# Altium schematic sheet SME_MicrocontrollerBoard_v2.1_Schematic.SchDoc(SME_MicrocontrollerBoard_v2.1_Schematic)
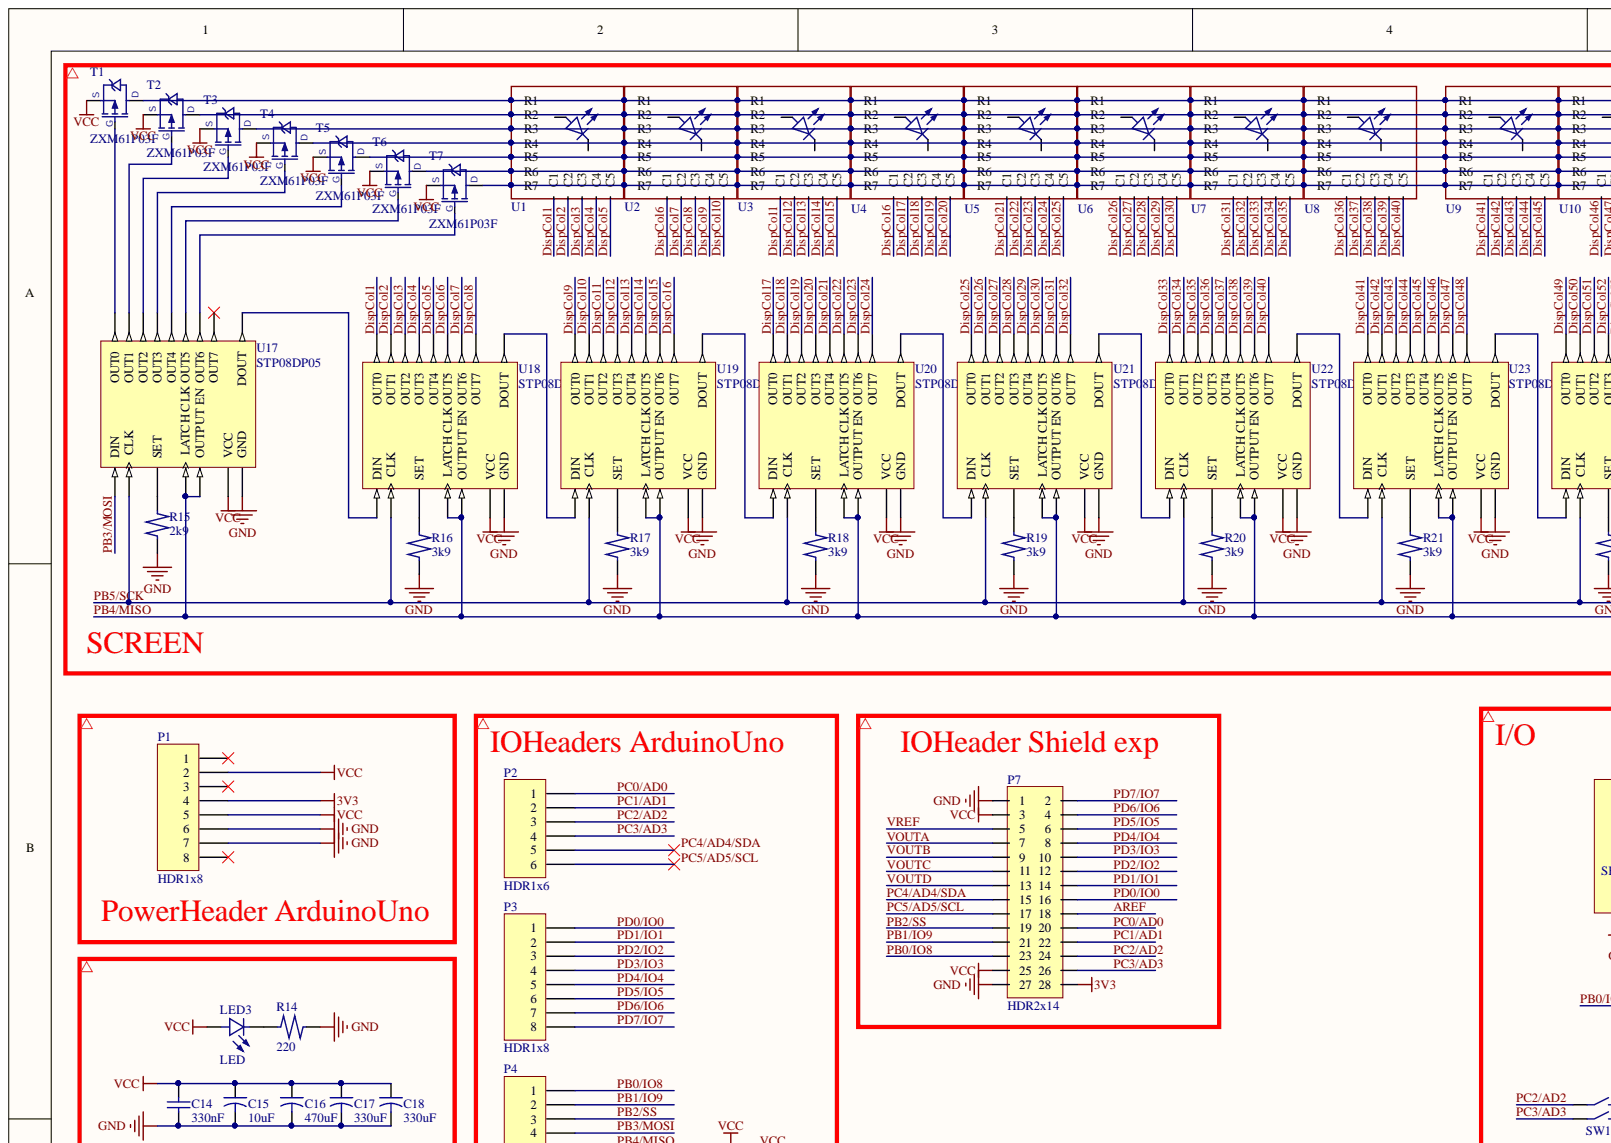
[diagram p0: SME_MicrocontrollerBoard_v2.1_Schematic.SchDoc, top left]
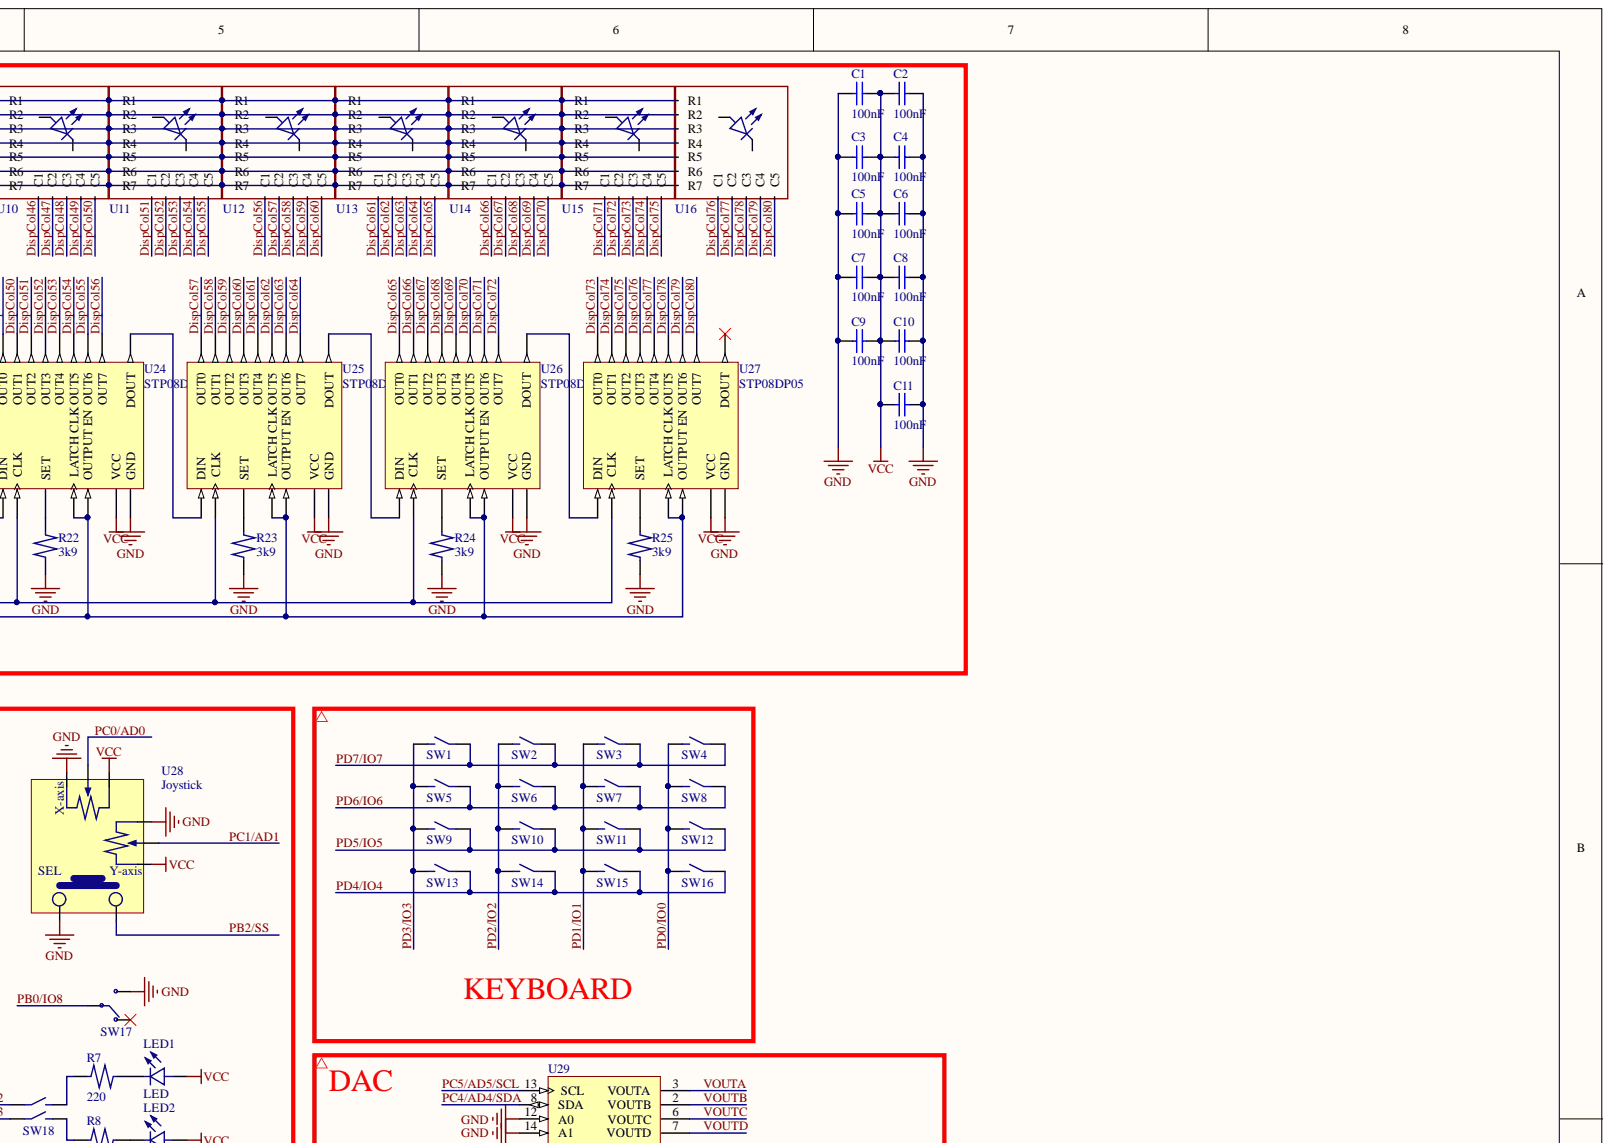
[diagram p1: SME_MicrocontrollerBoard_v2.1_Schematic.SchDoc, top right]
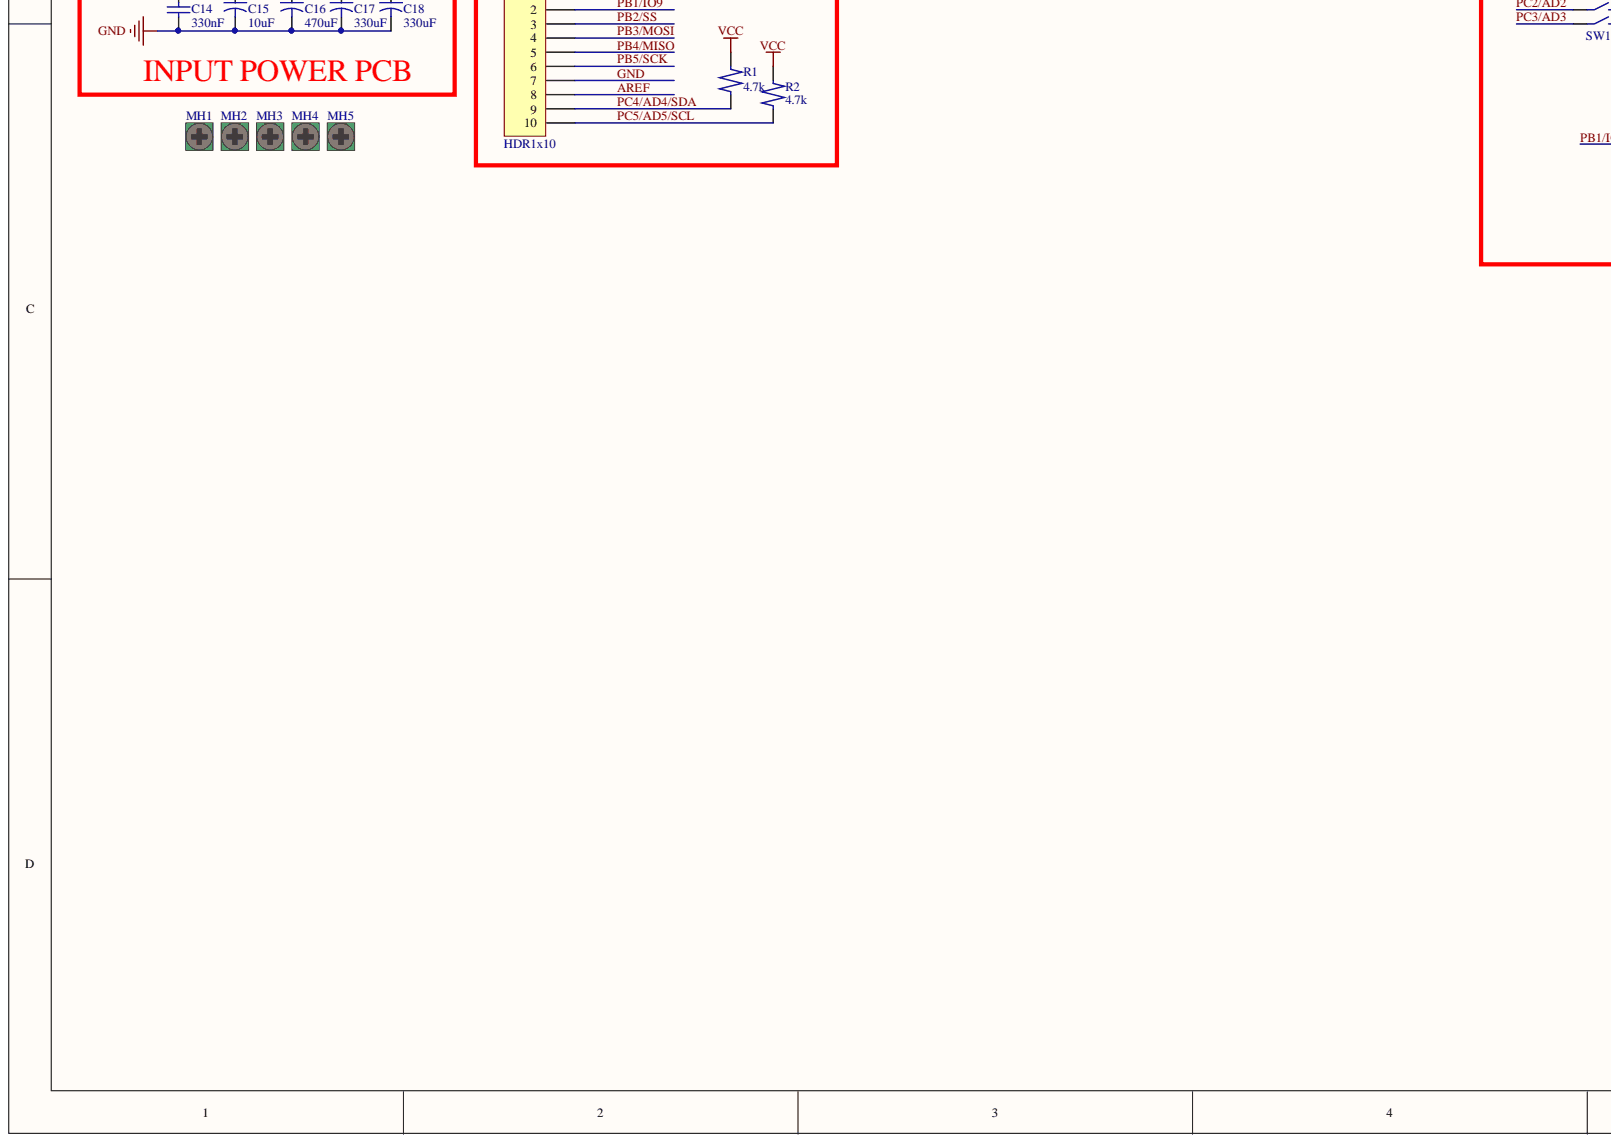
[diagram p2: SME_MicrocontrollerBoard_v2.1_Schematic.SchDoc, bottom left]
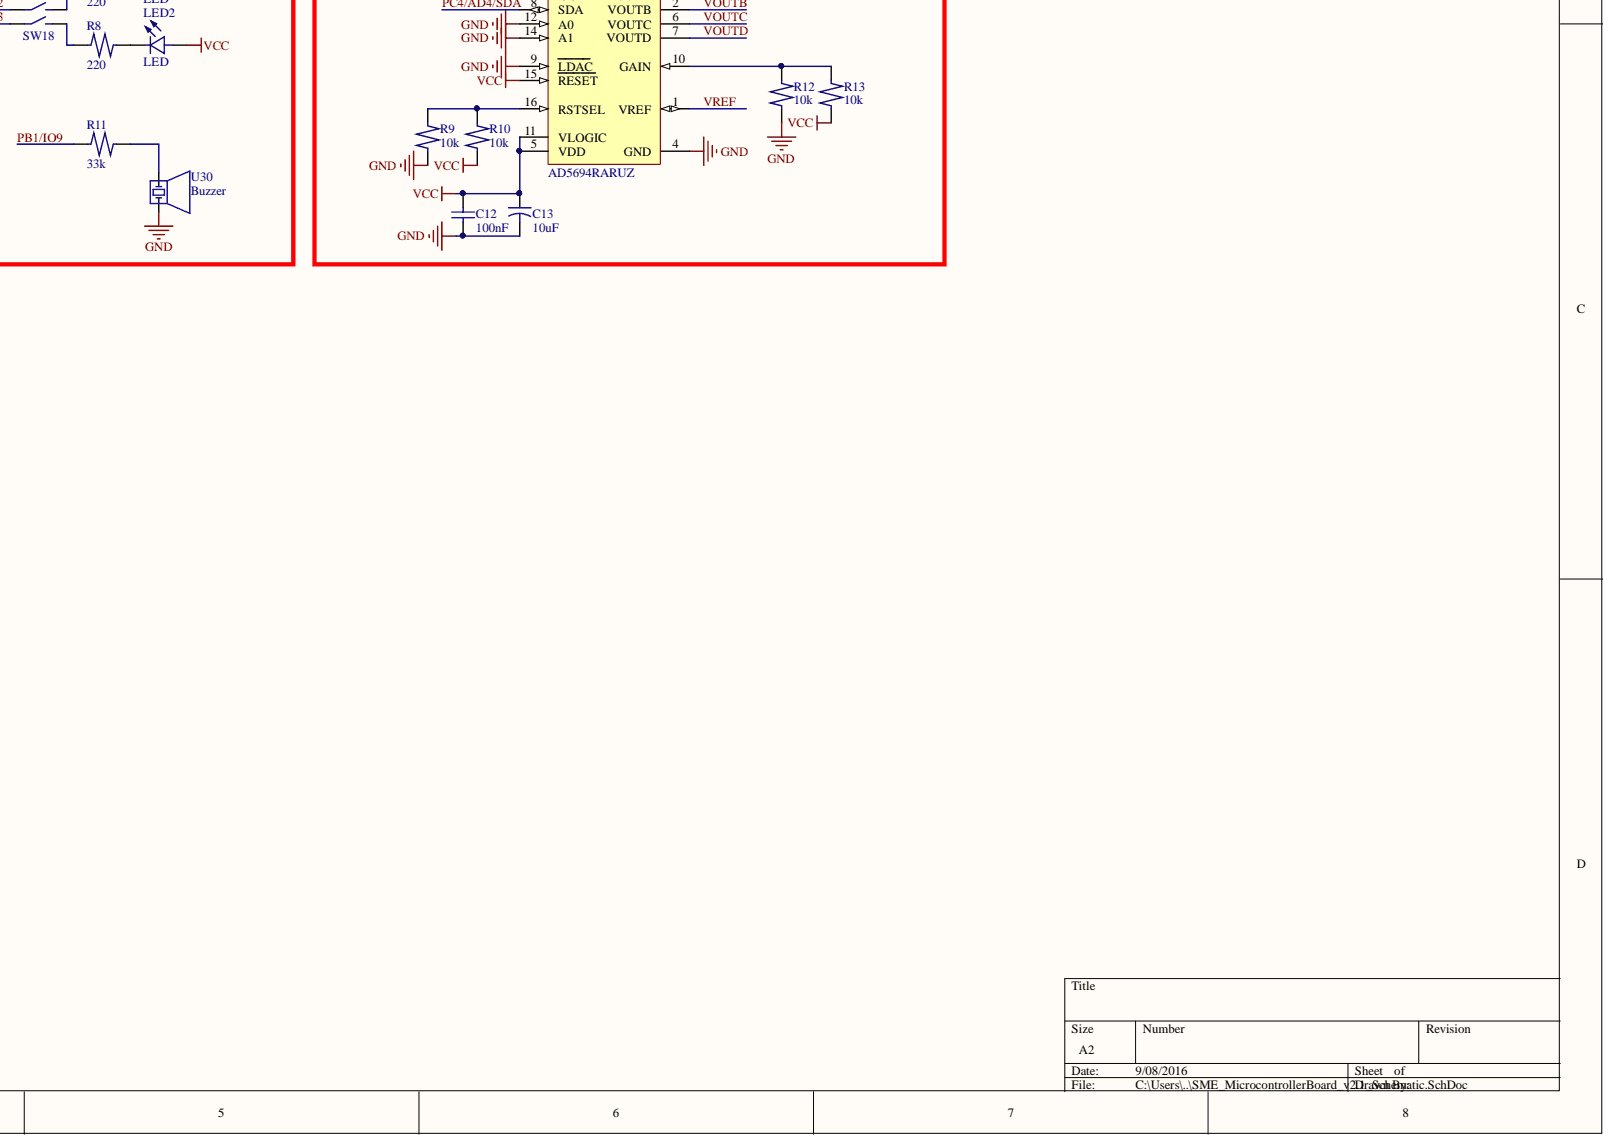
[diagram p3: SME_MicrocontrollerBoard_v2.1_Schematic.SchDoc, bottom right]

## Parts
C1  100nF  [CAPC0603(1608)_M]
C2  100nF  [CAPC0603(1608)_M]
C3  100nF  [CAPC0603(1608)_M]
C4  100nF  [CAPC0603(1608)_M]
C5  100nF  [CAPC0603(1608)_M]
C6  100nF  [CAPC0603(1608)_M]
C7  100nF  [CAPC0603(1608)_M]
C8  100nF  [CAPC0603(1608)_M]
C9  100nF  [CAPC0603(1608)_M]
C10  100nF  [CAPC0603(1608)_M]
C11  100nF  [CAPC0603(1608)_M]
C12  100nF  [CAPC0603(1608)_M]
C13  10uF  [CAPC1210(3225)_M_Polar]
C14  330nF  [CAPC0603(1608)_M]
C15  10uF  [CAPC1210(3225)_M_Polar]
C16  470uF  [CAPC1210(3225)_M_Polar]
C17  330uF  [CAPC_Gcase]
C18  330uF  [CAPC_Gcase]
LED1  LED  [LEDC0603(1608)]
LED2  LED  [LEDC0603(1608)]
LED3  LED  [LEDC0603(1608)]
MH1  Mounting Hole  [Hole_Mounting]  (value not drawn)
MH2  Mounting Hole  [Hole_Mounting]  (value not drawn)
MH3  Mounting Hole  [Hole_Mounting]  (value not drawn)
MH4  Mounting Hole  [Hole_Mounting]  (value not drawn)
MH5  Mounting Hole  [Hole_Mounting]  (value not drawn)
P1  HDR1x8  [HDR1X8_SMD]
P2  HDR1x6  [HDR1X6_SMD]
P3  HDR1x8  [HDR1X8_SMD]
P4  HDR1x10  [HDR1X10_SMD]
P7  HDR2x14  [HDR2X14_Female_RightAngle]
R1  4.7k  [RESC0603(1608)_M]
R2  4.7k  [RESC0603(1608)_M]
R7  220  [RESC0603(1608)_M]
R8  220  [RESC0603(1608)_M]
R9  10k  [RESC0603(1608)_M]
R10  10k  [RESC0603(1608)_M]
R11  33k  [RESC0603(1608)_M]
R12  10k  [RESC0603(1608)_M]
R13  10k  [RESC0603(1608)_M]
R14  220  [RESC0603(1608)_M]
R15  2k9  [RESC0603(1608)_M]
R16  3k9  [RESC0603(1608)_M]
R17  3k9  [RESC0603(1608)_M]
R18  3k9  [RESC0603(1608)_M]
R19  3k9  [RESC0603(1608)_M]
R20  3k9  [RESC0603(1608)_M]
R21  3k9  [RESC0603(1608)_M]
R22  3k9  [RESC0603(1608)_M]
R23  3k9  [RESC0603(1608)_M]
R24  3k9  [RESC0603(1608)_M]
R25  3k9  [RESC0603(1608)_M]
SW1  Switch_Tactile  [Switch_tactile_SMD_12x12]  (value not drawn)
SW2  Switch_Tactile  [Switch_tactile_SMD_12x12]  (value not drawn)
SW3  Switch_Tactile  [Switch_tactile_SMD_12x12]  (value not drawn)
SW4  Switch_Tactile  [Switch_tactile_SMD_12x12]  (value not drawn)
SW5  Switch_Tactile  [Switch_tactile_SMD_12x12]  (value not drawn)
SW6  Switch_Tactile  [Switch_tactile_SMD_12x12]  (value not drawn)
SW7  Switch_Tactile  [Switch_tactile_SMD_12x12]  (value not drawn)
SW8  Switch_Tactile  [Switch_tactile_SMD_12x12]  (value not drawn)
SW9  Switch_Tactile  [Switch_tactile_SMD_12x12]  (value not drawn)
SW10  Switch_Tactile  [Switch_tactile_SMD_12x12]  (value not drawn)
SW11  Switch_Tactile  [Switch_tactile_SMD_12x12]  (value not drawn)
SW12  Switch_Tactile  [Switch_tactile_SMD_12x12]  (value not drawn)
SW13  Switch_Tactile  [Switch_tactile_SMD_12x12]  (value not drawn)
SW14  Switch_Tactile  [Switch_tactile_SMD_12x12]  (value not drawn)
SW15  Switch_Tactile  [Switch_tactile_SMD_12x12]  (value not drawn)
SW16  Switch_Tactile  [Switch_tactile_SMD_12x12]  (value not drawn)
SW17  Switch_Rocker  [Switch_Rocker_NKK_GW12_series]  (value not drawn)
SW18  Switch_DIP_2  [Switch_Dip_2]  (value not drawn)
T1  ZXM61P03F  [SOT23-3ld_M_0.95PITCH_3x1.4BODY]
T2  ZXM61P03F  [SOT23-3ld_M_0.95PITCH_3x1.4BODY]
T3  ZXM61P03F  [SOT23-3ld_M_0.95PITCH_3x1.4BODY]
T4  ZXM61P03F  [SOT23-3ld_M_0.95PITCH_3x1.4BODY]
T5  ZXM61P03F  [SOT23-3ld_M_0.95PITCH_3x1.4BODY]
T6  ZXM61P03F  [SOT23-3ld_M_0.95PITCH_3x1.4BODY]
T7  ZXM61P03F  [SOT23-3ld_M_0.95PITCH_3x1.4BODY]
U1  DOTmatrix_5x7_CC  [DOTmatrix_5x7_0.7"]  (value not drawn)
U2  DOTmatrix_5x7_CC  [DOTmatrix_5x7_0.7"]  (value not drawn)
U3  DOTmatrix_5x7_CC  [DOTmatrix_5x7_0.7"]  (value not drawn)
U4  DOTmatrix_5x7_CC  [DOTmatrix_5x7_0.7"]  (value not drawn)
U5  DOTmatrix_5x7_CC  [DOTmatrix_5x7_0.7"]  (value not drawn)
U6  DOTmatrix_5x7_CC  [DOTmatrix_5x7_0.7"]  (value not drawn)
U7  DOTmatrix_5x7_CC  [DOTmatrix_5x7_0.7"]  (value not drawn)
U8  DOTmatrix_5x7_CC  [DOTmatrix_5x7_0.7"]  (value not drawn)
U9  DOTmatrix_5x7_CC  [DOTmatrix_5x7_0.7"]  (value not drawn)
U10  DOTmatrix_5x7_CC  [DOTmatrix_5x7_0.7"]  (value not drawn)
U11  DOTmatrix_5x7_CC  [DOTmatrix_5x7_0.7"]  (value not drawn)
U12  DOTmatrix_5x7_CC  [DOTmatrix_5x7_0.7"]  (value not drawn)
U13  DOTmatrix_5x7_CC  [DOTmatrix_5x7_0.7"]  (value not drawn)
U14  DOTmatrix_5x7_CC  [DOTmatrix_5x7_0.7"]  (value not drawn)
U15  DOTmatrix_5x7_CC  [DOTmatrix_5x7_0.7"]  (value not drawn)
U16  DOTmatrix_5x7_CC  [DOTmatrix_5x7_0.7"]  (value not drawn)
U17  STP08DP05  [TSSOP-16ld_M_0.65Pitch_5X4.4body]
U18  STP08DP05  [TSSOP-16ld_M_0.65Pitch_5X4.4body]
U19  STP08DP05  [TSSOP-16ld_M_0.65Pitch_5X4.4body]
U20  STP08DP05  [TSSOP-16ld_M_0.65Pitch_5X4.4body]
U21  STP08DP05  [TSSOP-16ld_M_0.65Pitch_5X4.4body]
U22  STP08DP05  [TSSOP-16ld_M_0.65Pitch_5X4.4body]
U23  STP08DP05  [TSSOP-16ld_M_0.65Pitch_5X4.4body]
U24  STP08DP05  [TSSOP-16ld_M_0.65Pitch_5X4.4body]
U25  STP08DP05  [TSSOP-16ld_M_0.65Pitch_5X4.4body]
U26  STP08DP05  [TSSOP-16ld_M_0.65Pitch_5X4.4body]
U27  STP08DP05  [TSSOP-16ld_M_0.65Pitch_5X4.4body]
U28  Joystick  [Joystick_ALPS_RKJXK122400Y]
U29  AD5694RARUZ  [RU-16_N]
U30  Buzzer  [Buzzer, IMO 41.T70P015H-LF]

## Nets
3V3: P1.4 P7.28
VCC: C1.2 C2.1 C3.2 C4.1 C5.2 C6.1 C7.2 C8.1 C9.2 C10.1 C11.1 C12.2 C13.1 C14.2 C15.1 C16.1 C17.1 C18.1 LED1.1 LED2.1 LED3.1 P1.2 P1.5 P7.3 P7.25 R1.2 R2.2 R10.1 R13.1 T1.2 T2.2 T3.2 T4.2 T5.2 T6.2 T7.2 U17.16(VCC) U18.16(VCC) U19.16(VCC) U20.16(VCC) U21.16(VCC) U22.16(VCC) U23.16(VCC) U24.16(VCC) U25.16(VCC) U26.16(VCC) U27.16(VCC) U28.X3 U28.Y3 U29.5(VDD) U29.11(VLOGIC) U29.15(RESET)
AREF: P4.8 P7.18
DISPCO11: U3.1 U19.7(OUT2)
DISPCO16: U4.1 U19.12(OUT7)
DISPCOL1: U1.1 U18.5(OUT0)
DISPCOL2: U1.3 U18.6(OUT1)
DISPCOL3: U1.10(C3) U18.7(OUT2)
DISPCOL4: U1.7 U18.8(OUT3)
DISPCOL5: U1.8 U18.9(OUT4)
DISPCOL6: U2.1 U18.10(CLK_OUT5)
DISPCOL7: U2.3 U18.11(EN_OUT6)
DISPCOL8: U2.10(C3) U18.12(OUT7)
DISPCOL9: U2.7 U19.5(OUT0)
DISPCOL10: U2.8 U19.6(OUT1)
DISPCOL12: U3.3 U19.8
DISPCOL13: U3.10 U19.9(OUT4)
DISPCOL14: U3.7 U19.10(CLK_OUT5)
DISPCOL15: U3.8 U19.11(EN_OUT6)
DISPCOL17: U4.3 U20.5(OUT0)
DISPCOL18: U4.10 U20.6(OUT1)
DISPCOL19: U4.7 U20.7(OUT2)
DISPCOL20: U4.8 U20.8(OUT3)
DISPCOL21: U5.1 U20.9(OUT4)
DISPCOL22: U5.3 U20.10(CLK_OUT5)
DISPCOL23: U5.10(C3) U20.11(EN_OUT6)
DISPCOL24: U5.7 U20.12(OUT7)
DISPCOL25: U5.8 U21.5(OUT0)
DISPCOL26: U6.1 U21.6(OUT1)
DISPCOL27: U6.3 U21.7(OUT2)
DISPCOL28: U6.10(C3) U21.8(OUT3)
DISPCOL29: U6.7 U21.9(OUT4)
DISPCOL30: U6.8 U21.10(CLK_OUT5)
DISPCOL31: U7.1 U21.11(EN_OUT6)
DISPCOL32: U7.3 U21.12(OUT7)
DISPCOL33: U7.10 U22.5(OUT0)
DISPCOL34: U7.7 U22.6(OUT1)
DISPCOL35: U7.8 U22.7(OUT2)
DISPCOL36: U8.1 U22.8(OUT3)
DISPCOL37: U8.3 U22.9(OUT4)
DISPCOL38: U8.10(C3) U22.10(CLK_OUT5)
DISPCOL39: U8.7 U22.11(EN_OUT6)
DISPCOL40: U8.8 U22.12(OUT7)
DISPCOL41: U9.1 U23.5(OUT0)
DISPCOL42: U9.3 U23.6(OUT1)
DISPCOL43: U9.10 U23.7(OUT2)
DISPCOL44: U9.7 U23.8(OUT3)
DISPCOL45: U9.8 U23.9(OUT4)
DISPCOL46: U10.1(C1) U23.10(CLK_OUT5)
DISPCOL47: U10.3 U23.11(EN_OUT6)
DISPCOL48: U10.10(C3) U23.12(OUT7)
DISPCOL49: U10.7(C4) U24.5(OUT0)
DISPCOL50: U10.8(C5) U24.6(OUT1)
DISPCOL51: U11.1 U24.7(OUT2)
DISPCOL52: U11.3(C2) U24.8(OUT3)
DISPCOL53: U11.10(C3) U24.9(OUT4)
DISPCOL54: U11.7(C4) U24.10(CLK_OUT5)
DISPCOL55: U11.8 U24.11(EN_OUT6)
DISPCOL56: U12.1(C1) U24.12(OUT7)
DISPCOL57: U12.3(C2) U25.5(OUT0)
DISPCOL58: U12.10(C3) U25.6(OUT1)
DISPCOL59: U12.7 U25.7(OUT2)
DISPCOL60: U12.8(C5) U25.8(OUT3)
DISPCOL61: U13.1(C1) U25.9(OUT4)
DISPCOL62: U13.3(C2) U25.10(CLK_OUT5)
DISPCOL63: U13.10 U25.11(EN_OUT6)
DISPCOL64: U13.7(C4) U25.12(OUT7)
DISPCOL65: U13.8(C5) U26.5(OUT0)
DISPCOL66: U14.1(C1) U26.6(OUT1)
DISPCOL67: U14.3 U26.7(OUT2)
DISPCOL68: U14.10(C3) U26.8(OUT3)
DISPCOL69: U14.7(C4) U26.9(OUT4)
DISPCOL70: U14.8(C5) U26.10(CLK_OUT5)
DISPCOL71: U15.1 U26.11(EN_OUT6)
DISPCOL72: U15.3(C2) U26.12(OUT7)
DISPCOL73: U15.10(C3) U27.5(OUT0)
DISPCOL74: U15.7(C4) U27.6(OUT1)
DISPCOL75: U15.8 U27.7(OUT2)
DISPCOL76: U16.1(C1) U27.8(OUT3)
DISPCOL77: U16.3(C2) U27.9(OUT4)
DISPCOL78: U16.10(C3) U27.10(CLK_OUT5)
DISPCOL79: U16.7 U27.11(EN_OUT6)
DISPCOL80: U16.8(C5) U27.12(OUT7)
GND: C1.1 C2.2 C3.1 C4.2 C5.1 C6.2 C7.1 C8.2 C9.1 C10.2 C11.2 C12.1 C13.2 C14.1 C15.2 C16.2 C17.2 C18.2 P1.6 P1.7 P4.7 P7.1 P7.27 R9.1 R12.1 R14.2 R15.1 R16.1 R17.1 R18.1 R19.1 R20.1 R21.1 R22.1 R23.1 R24.1 R25.1 SW17.1 U17.1(GND) U18.1(GND) U19.1(GND) U20.1(GND) U21.1(GND) U22.1(GND) U23.1(GND) U24.1(GND) U25.1(GND) U26.1(GND) U27.1(GND) U28.SEL1 U28.X1(X-axis) U28.Y1 U29.4(GND) U29.9(LDAC) U29.12(A0) U29.14(A1) U30.1
PB0/IO8: P4.1 P7.23 SW17.2
PB1/IO9: P4.2 P7.21 R11.2
PB2/SS: P4.3 P7.19 U28.SEL2
PB3/MOSI: P4.4 U17.2(DIN)
PB4/MISO: P4.5 U17.4 U17.13 U18.4 U18.13 U19.4 U19.13 U20.4 U20.13 U21.4 U21.13 U22.4 U22.13 U23.4 U23.13 U24.4 U24.13 U25.4 U25.13 U26.4 U26.13 U27.4 U27.13
PB5/SCK: P4.6 U17.3(CLK) U18.3(CLK) U19.3(CLK) U20.3(CLK) U21.3(CLK) U22.3(CLK) U23.3(CLK) U24.3(CLK) U25.3(CLK) U26.3(CLK) U27.3(CLK)
PC0/AD0: P2.1 P7.20 U28.X2
PC1/AD1: P2.2 P7.22 U28.Y2
PC2/AD2: P2.3 P7.24 SW18.1
PC3/AD3: P2.4 P7.26 SW18.3
PC4/AD4/SDA: P4.9 P7.15 R1.1 U29.8(SDA)
PC5/AD5/SCL: P4.10 P7.17 R2.1 U29.13(SCL)
PD0/IO0: P3.1 P7.16 SW4.2 SW8.2 SW12.2 SW16.2
PD1/IO1: P3.2 P7.14 SW3.2 SW7.2 SW11.2 SW15.2
PD2/IO2: P3.3 P7.12 SW2.2 SW6.2 SW10.2 SW14.2
PD3/IO3: P3.4 P7.10 SW1.2 SW5.2 SW9.2 SW13.2
PD4/IO4: P3.5 P7.8 SW13.1 SW14.1 SW15.1 SW16.1
PD5/IO5: P3.6 P7.6 SW9.1 SW10.1 SW11.1 SW12.1
PD6/IO6: P3.7 P7.4 SW5.1 SW6.1 SW7.1 SW8.1
PD7/IO7: P3.8 P7.2 SW1.1 SW2.1 SW3.1 SW4.1
VOUTA: P7.7 U29.3(VOUTA)
VOUTB: P7.9 U29.2(VOUTB)
VOUTC: P7.11 U29.6(VOUTC)
VOUTD: P7.13 U29.7(VOUTD)
VREF: P7.5 U29.1(VREF)
NetLED1_2: LED1.2 R7.1
NetLED2_2: LED2.2 R8.1
NetLED3_2: LED3.2 R14.1
NetR7_2: R7.2 SW18.2
NetR8_2: R8.2 SW18.4
NetR9_2: R9.2 R10.2 U29.16(RSTSEL)
NetR11_1: R11.1 U30.2
NetR12_2: R12.2 R13.2 U29.10(GAIN)
NetR15_2: R15.2 U17.15(SET)
NetR16_2: R16.2 U18.15(SET)
NetR17_2: R17.2 U19.15
NetR18_2: R18.2 U20.15(SET)
NetR19_2: R19.2 U21.15(SET)
NetR20_2: R20.2 U22.15(SET)
NetR21_2: R21.2 U23.15(SET)
NetR22_2: R22.2 U24.15(SET)
NetR23_2: R23.2 U25.15(SET)
NetR24_2: R24.2 U26.15(SET)
NetR25_2: R25.2 U27.15(SET)
NetT1_1: T1.1 U17.5(OUT0)
NetT1_3: T1.3 U1.12 U2.12 U3.12 U4.12 U5.12 U6.12 U7.12 U8.12 U9.12 U10.12 U11.12 U12.12 U13.12 U14.12 U15.12 U16.12
NetT2_1: T2.1 U17.6(OUT1)
NetT2_3: T2.3 U1.11 U2.11(R2) U3.11(R2) U4.11 U5.11 U6.11(R2) U7.11 U8.11 U9.11 U10.11 U11.11 U12.11(R2) U13.11 U14.11 U15.11 U16.11(R2)
NetT3_1: T3.1 U17.7(OUT2)
NetT3_3: T3.3 U1.2(R3) U2.2(R3) U3.2(R3) U4.2(R3) U5.2(R3) U6.2(R3) U7.2(R3) U8.2(R3) U9.2(R3) U10.2 U11.2 U12.2(R3) U13.2 U14.2 U15.2 U16.2(R3)
NetT4_1: T4.1 U17.8(OUT3)
NetT4_3: T4.3 U1.9(R4) U2.9(R4) U3.9(R4) U4.9(R4) U5.9(R4) U6.9(R4) U7.9(R4) U8.9(R4) U9.9(R4) U10.9 U11.9 U12.9(R4) U13.9 U14.9 U15.9 U16.9(R4)
NetT5_1: T5.1 U17.9(OUT4)
NetT5_3: T5.3 U1.4 U2.4(R5) U3.4(R5) U4.4 U5.4 U6.4(R5) U7.4 U8.4 U9.4 U10.4 U11.4 U12.4 U13.4 U14.4 U15.4 U16.4
NetT6_1: T6.1 U17.10(CLK_OUT5)
NetT6_3: T6.3 U1.5(R6) U2.5(R6) U3.5(R6) U4.5(R6) U5.5(R6) U6.5(R6) U7.5(R6) U8.5(R6) U9.5(R6) U10.5 U11.5 U12.5(R6) U13.5 U14.5 U15.5 U16.5(R6)
NetT7_1: T7.1 U17.11(EN_OUT6)
NetT7_3: T7.3 U1.6(R7) U2.6(R7) U3.6(R7) U4.6(R7) U5.6(R7) U6.6(R7) U7.6(R7) U8.6(R7) U9.6(R7) U10.6 U11.6 U12.6(R7) U13.6 U14.6 U15.6 U16.6(R7)
NetU17_14: U17.14(DOUT) U18.2(DIN)
NetU18_14: U18.14(DOUT) U19.2(DIN)
NetU19_14: U19.14(DOUT) U20.2(DIN)
NetU20_14: U20.14(DOUT) U21.2(DIN)
NetU21_14: U21.14(DOUT) U22.2(DIN)
NetU22_14: U22.14(DOUT) U23.2(DIN)
NetU23_14: U23.14(DOUT) U24.2(DIN)
NetU24_14: U24.14(DOUT) U25.2(DIN)
NetU25_14: U25.14(DOUT) U26.2(DIN)
NetU26_14: U26.14(DOUT) U27.2(DIN)

Not connected: P1 1 3 8; P2 5 6; SW17 3; U17 12(OUT7); U27 14(DOUT)

## Library data of the parts
C1-C12 C14 = Capacitor: SMD Capacitor; Component Kind: Standard; Library Name: VUBLib_Vault_INTLib.IntLib
  C1-C12: Value: 100nF
  C14: Value: 330nF
C13 C15-C18 = Capacitor_Polar: SMD Capacitor_Polar; Component Kind: Standard; Library Name: VUBLib_Vault_INTLib.IntLib
  C13 C15: Value: 10uF
  C16: Value: 470uF
  C17 C18: Value: 330uF
LED1-LED3 = LED: LED with various footprints; Component Kind: Standard; Library Name: VUBLib_Vault_INTLib.IntLib
MH1-MH5 = Hole_Mounting: Mounting hole in different footprints; Component Kind: Standard; Library Name: VUBLib_Vault_INTLib.IntLib
P1 P3 = HDR1x8: Header, 8-Pin; Component Kind: Standard; Library Name: VUBLib_Vault_INTLib.IntLib
P2 = HDR1x6: Header, 6-Pin; Component Kind: Standard; Library Name: VUBLib_Vault_INTLib.IntLib
P4 = HDR1x10: Header, 10-Pin; Component Kind: Standard; Library Name: VUBLib_Vault_INTLib.IntLib
P7 = HDR2x14: Header, 14-Pin, Dual row; Component Kind: Standard; Library Name: VUBLib_Vault_INTLib.IntLib
R1 R2 R7-R25 = Resistor: SMD Resistor; Component Kind: Standard; Library Name: VUBLib_Vault_INTLib.IntLib
  R1 R2: Value: 4.7k
  R7 R8 R14: Value: 220
  R9 R10 R12 R13: Value: 10k
  R11: Value: 33k
  R15: Value: 2k9
  R16-R25: Value: 3k9
SW1-SW16 = Switch_Tactile: Switch Tactile in different footprints; Component Kind: Standard; Library Name: VUBLib_Vault_INTLib.IntLib
SW17 = Switch_Rocker: Switch Rocker in different footprints; Component Kind: Standard; Library Name: VUBLib_Vault_INTLib.IntLib
SW18 = Switch_DIP_2: Switch DIP in different footprints,2 leads; Component Kind: Standard; Library Name: VUBLib_Vault_INTLib.IntLib
T1-T7 = ZXM61P03F: 30V P-CHANNEL ENHANCEMENT MODE MOSFET; Component Kind: Standard; Library Name: VUBLib_Vault_INTLib.IntLib
U1-U16 = DOTmatrix_5x7_CC: 5x7 DOT matrix, Column Cathode; Component Kind: Standard; Library Name: VUBLib_Vault_INTLib.IntLib
U17-U27 = STP08DP05: 8-Bit Serial-Input Shift  Register with Latched  3-State Outputs and current reference; Component Kind: Standard; Library Name: VUBLib_Vault_INTLib.IntLib
U28 = JoyStick_2axis_select: Joystick, 2 axis, select; Component Kind: Standard; Library Name: VUBLib_Vault_INTLib.IntLib
U29 = CMP-0318-01679-2: Quad 12-Bit nanoDAC+ with I2C Interface and 2 ppm/degC On-Chip Reference, -40 to +105 degC, RU-16, Pb-Free, Tube; Manufacturer: Analog Devices; # DAC Outputs: 4; Max V+: 5.5V; Resolution (Bits): 12; Settling Time: 5Âµs; Single Supply: Yes; Code_JEDEC: MO-153-AB; Component Kind: Standard; ComponentLink1Description: Manufacturer URL; ComponentLink1URL: http://www.analog.com/en/index.html; ComponentLink2Description: Datasheet; ComponentLink2URL: http://www.analog.com/static/imported-files/data_sheets/AD5696R_AD5695R_AD5694R.pdf; ComponentLink3Description: Package Specification; ComponentLink3URL: http://www.analog.com/static/imported-files/packages/36244347913743854010197452789RU16.pdf; ComponentLink4Description: Product URL; ComponentLink4URL: http://www.analog.com/en/digital-to-analog-converters/da-converters/ad5694r/products/product.html; DatasheetVersion: Rev.0; Generic Part Number: AD5694R; Library Name: Altium Content Vault; Mounting Technology: Surface Mount; PackageDescription: 16-Lead Thin Shrink Small Outline Package [TSSOP]; PackageReference: RU-16; PackageVersion: Nov-2002; Packing: Tube; PartNumber: AD5694RARUZ; RevisionNote: Component URL fixed; RoHS: Y
U30 = Buzzer: Buzzer in different footprints; Component Kind: Standard; Library Name: VUBLib_Vault_INTLib.IntLib
